# Altium schematic sheet SynchronousRectifier.SchDoc
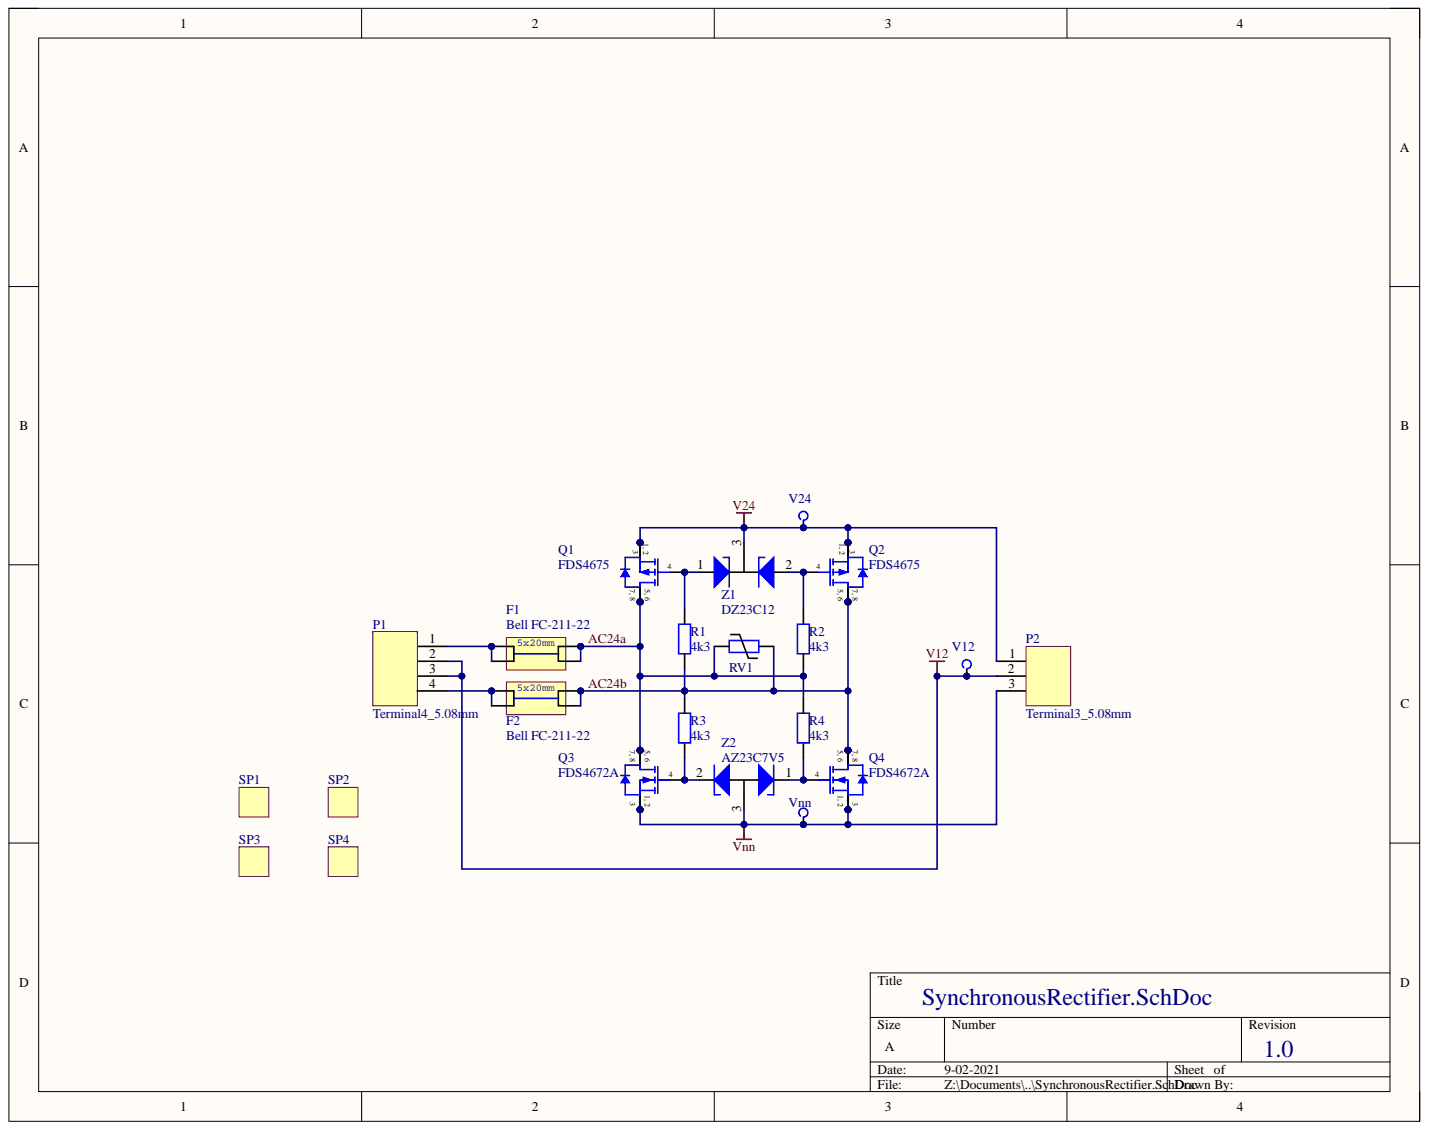
[diagram p0: SynchronousRectifier.SchDoc]

## Parts
F1  Bell FC-211-22  [FUSE_CLIP_5x20]
F2  Bell FC-211-22  [FUSE_CLIP_5x20]
P1  Terminal4_5.08mm  [MOLEX-39544-3004]
P2  Terminal3_5.08mm  [MOLEX-39544-3003]
Q1  FDS4675  [SO-8_M]
Q2  FDS4675  [SO-8_M]
Q3  FDS4672A  [SO-8_M]
Q4  FDS4672A  [SO-8_M]
R1  4k3  [CR1608-0603_N]
R2  4k3  [CR1608-0603_N]
R3  4k3  [CR1608-0603_N]
R4  4k3  [CR1608-0603_N]
RV1  V33MLA1206NHAUTO  [VR3216-1206]  (value not drawn)
SP1  Spacer-3mm-tapped x 10mm  [Spacer-3mm tapped x 10 mm]  (value not drawn)
SP2  Spacer-3mm-tapped x 10mm  [Spacer-3mm tapped x 10 mm]  (value not drawn)
SP3  Spacer-3mm-tapped x 10mm  [Spacer-3mm tapped x 10 mm]  (value not drawn)
SP4  Spacer-3mm-tapped x 10mm  [Spacer-3mm tapped x 10 mm]  (value not drawn)
V12  Power 12V FWR  [PCBPIN - 0.7mm]  (value not drawn)  (no BOM)
V24  Power 24V FWR  [PCBPIN - 0.7mm]  (value not drawn)  (no BOM)
Vnn  Power Ground  [PCBPIN - 0.7mm]  (value not drawn)  (no BOM)
Z1  DZ23C12  [SOT23_M]
Z2  AZ23C7V5  [SOT23_M]

## Nets
V12: P1.2 P1.3 P2.2 V12.1
V24: P2.1 Q1.1 Q1.2 Q1.3 Q2.1 Q2.2 Q2.3 V24.1 Z1.3
Vnn: P2.3 Q3.1 Q3.2 Q3.3 Q4.1 Q4.2 Q4.3 Vnn.1 Z2.3
AC24a: F1.2(5x20mm) F1.2a Q1.5 Q1.6 Q1.7 Q1.8 Q3.5 Q3.6 Q3.7 Q3.8 R2.1 R4.2 RV1.2
AC24b: F2.2(5x20mm) F2.2a Q2.5 Q2.6 Q2.7 Q2.8 Q4.5 Q4.6 Q4.7 Q4.8 R1.1 R3.2 RV1.1
NetF1_1: F1.1 F1.1a P1.1
NetF2_1: F2.1 F2.1a P1.4
NetQ1_4: Q1.4 R1.2 Z1.1
NetQ2_4: Q2.4 R2.2 Z1.2
NetQ3_4: Q3.4 R3.1 Z2.2
NetQ4_4: Q4.4 R4.1 Z2.1

## Library data of the parts
F1 F2 = FUSE_CLIP_5x20: 5x20mm fuse clip PCB Mount; Value: 10 A; Component Kind: Standard; Digikey: 507-1415-ND; Library Name: MySchLib.schlib
P1 = Terminal4_5.08mm: MULTICOMP MC24368 TERMINAL BLOCK EUROSTYLE, 4 POSITION, 26-12AWG, 5.08mm; Component Kind: Standard; Farnell PN: 1624302; Library Name: MyLibrary.IntLib
P2 = Terminal3_5.08mm: MULTICOMP MC24367 TERMINAL BLOCK EUROSTYLE, 3 POSITION, 26-12AWG, 5.08mm; Component Kind: Standard; Library Name: MyLibrary.IntLib
Q1 Q2 = FDS4675: P-Channel Power MOSFET, 11 A, 40 V, RDS(ON) = 0.013 ohm @ VGS = -10   VRDS(ON) = 0.017 ohm @ VGS =   -4.5 VC; Manufacturer: Fairchild Semiconductor; Drain-Source On Resistance-Max: 13mO; Drain-to-Source Voltage [Vdss]: 40V; Package Version: Rev. 16, 08/2015; Qg Gate Charge: 56nC; Rated Power Dissipation: 2.4W; Channel Type: P-Channel; Component Kind: Standard; Datasheet URL: https://www.fairchildsemi.com/datasheets/FD/FDS4675.pdf; Datasheet Version: Rev. C(W), 02/2001; Library Name: MySchLib.schlib; Manufacturer URL: https://www.fairchildsemi.com/; Mounting Technology: SurfaceMount; Package Description: 8-Pin Small Outline Integrated Circuit, Body 4.9 x 3.9 mm, Pitch 1.27 mm; Package Reference: SO-8; Package URL: https://www.fairchildsemi.com/package-drawings/M0/M08A.pdf; Simulation: FDS4675; Temperature: 25
Q3 Q4 = FDS4672A: N-Channel Power MOSFET, 11 A, 40 V. RDS(ON)  = .013 ohm @ VGS = 4.5 V; Manufacturer: Fairchild Semiconductor; Channel Type: P-Channel; Drain-Source On Resistance-Max: 13mO; Drain-to-Source Voltage [Vdss]: 40V; Package Version: Rev. 16, 08/2015; Qg Gate Charge: 56nC; Rated Power Dissipation: 2.4W; Component Kind: Standard; Datasheet URL: https://www.fairchildsemi.com/datasheets/FD/FDS4675.pdf; Datasheet Version: Rev. C(W), 02/2001; LCSC: C725034; Library Name: MySchLib.schlib; Manufacturer URL: https://www.fairchildsemi.com/; Mounting Technology: SurfaceMount; Package Description: 8-Pin Small Outline Integrated Circuit, Body 4.9 x 3.9 mm, Pitch 1.27 mm; Package Reference: SO-8; Package URL: https://www.fairchildsemi.com/package-drawings/M0/M08A.pdf; Simulation: FDS4672A
R1-R4 = Res_CR0603: Resistor SMT 0603; Manufacturer: Generic Components; Class: Passive; Component Kind: Standard; LCSC: C23159; Library Name: MySchLib.schlib; Published: 08/06/2000; Signal Integrity: Res; Simulation: RESISTOR; SubClass: Resistor; Value: 4k3; Publisher: Altium Hobart Technology Centre
RV1 = V33MLA1206NHAUTO: V33MLA1206NH - TVS Varistor, 26 V, 33 V, MLA Series, 75 V, 1206 [3216 Metric], Multilayer Varistor (MLV); Manufacturer: Generic Components; Class: Passive; SubClass: Resistor; Component Kind: Standard; Digikey: F11298CT-ND; Farnell PN: 1816942; Library Name: MySchLib.schlib; Published: 08/06/2000; Publisher: Altium Hobart Technology Centre
SP1-SP4 = Spacer-3mm-tapped x 10mm: 6MM Spacer; Component Kind: Standard; Library Name: MyLibrary.IntLib
V12 V24 Vnn = TP: Test Point; Library Name: MySchLib.schlib
Z1 = DZ23Cxx: Dual silicon planar Zener diodes; Component Kind: Standard; Library Name: MySchLib.schlib
Z2 = AZ23Cxx: Dual silicon planar Zener diodes; Component Kind: Standard; Library Name: MySchLib.schlib
